# Altium schematic sheet Glue.SchDoc
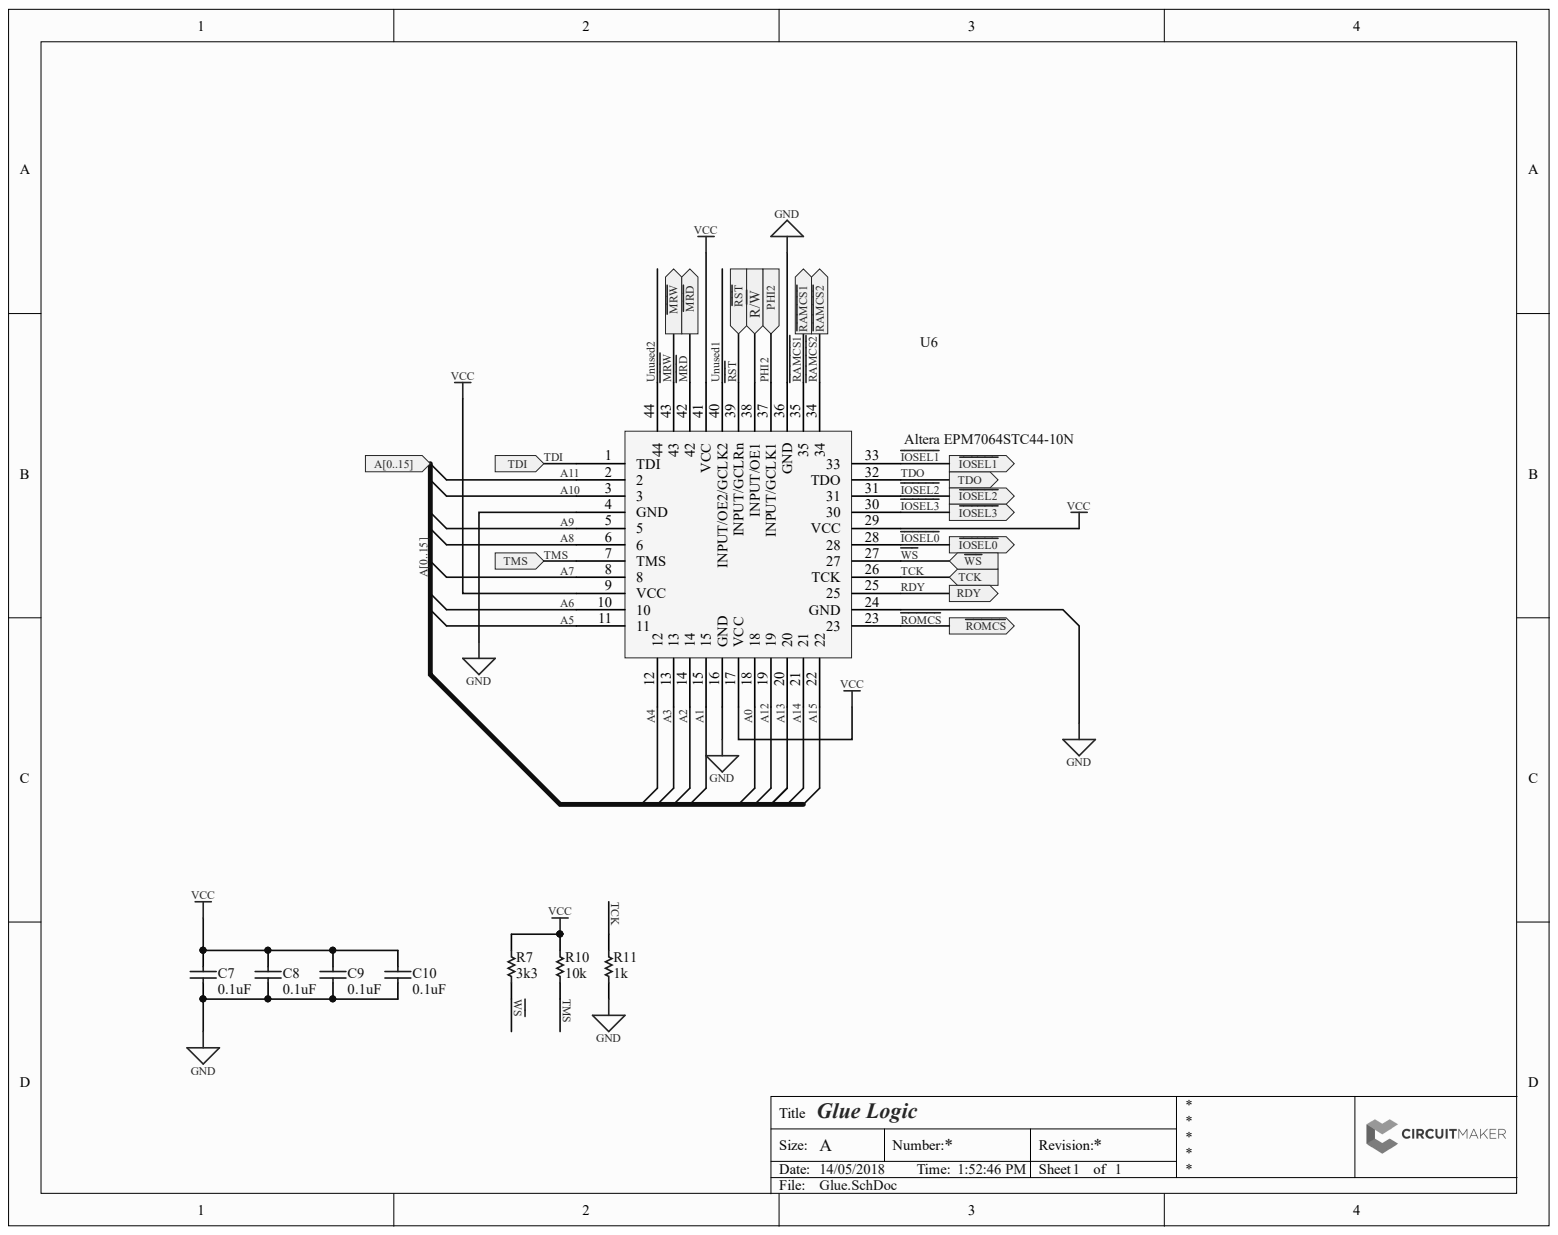
[diagram p0: Glue.SchDoc]

## Parts
C7  0.1uF  [CAPC1608X90X35NL15T15]
C8  0.1uF  [CAPC1608X90X35NL15T15]
C9  0.1uF  [CAPC1608X90X35NL15T15]
C10  0.1uF  [CAPC1608X90X35NL15T15]
R7  3k3  [RESC1608X55X30ML20T10]
R10  10k  [RESC1609X50X30NL10T20]
R11  1k  [RESC1608X55X30NL15T15]
U6  Altera EPM7064STC44-10N  [PCB-3kl8984h228uy08r94zq-1]

## Ports
A0, A1, A2, A3, A4, A5, A6, A7, A8, A9, A10, A11, A12, A13, A14, A15, A[0..15], PHI2, R/~{W}, RDY, TCK, TDI, TDO, TMS, ~{IOSEL0}, ~{IOSEL1}, ~{IOSEL2}, ~{IOSEL3}, ~{MRD}, ~{MRW}, ~{RAMCS1}, ~{RAMCS2}, ~{ROMCS}, ~{RST}, ~{WS}

## Nets
GND: C7.2 C8.2 C9.2 C10.2 R11.1 U6.4(GND) U6.16(GND) U6.24(GND) U6.36(GND)
VCC: C7.1 C8.1 C9.1 C10.1 R7.1 R10.2 U6.9(VCC) U6.17(VCC) U6.29(VCC) U6.41(VCC)
A0: U6.18 ; port A[0..15]  [also labelled A]
A1: U6.15 ; port A[0..15]  [also labelled A]
A2: U6.14 ; port A[0..15]  [also labelled A]
A3: U6.13 ; port A[0..15]  [also labelled A]
A4: U6.12 ; port A[0..15]  [also labelled A]
A5: U6.11 ; port A[0..15]  [also labelled A]
A6: U6.10 ; port A[0..15]  [also labelled A]
A7: U6.8 ; port A[0..15]  [also labelled A]
A8: U6.6 ; port A[0..15]  [also labelled A]
A9: U6.5 ; port A[0..15]  [also labelled A]
A10: U6.3 ; port A[0..15]  [also labelled A]
A11: U6.2 ; port A[0..15]  [also labelled A]
A12: U6.19 ; port A[0..15]  [also labelled A]
A13: U6.20 ; port A[0..15]  [also labelled A]
A14: U6.21 ; port A[0..15]  [also labelled A]
A15: U6.22 ; port A[0..15]  [also labelled A]
~{IOSEL0}: U6.28 ; port ~{IOSEL0}
~{IOSEL1}: U6.33 ; port ~{IOSEL1}
~{IOSEL2}: U6.31 ; port ~{IOSEL2}
~{IOSEL3}: U6.30 ; port ~{IOSEL3}
~{MRD}: U6.42 ; port ~{MRD}
~{MRW}: U6.43 ; port ~{MRW}
PHI2: U6.37(INPUT/GCLK1) ; port PHI2
R/~{W}: U6.38(INPUT/OE1) ; port R/~{W}
~{RAMCS1}: U6.35 ; port ~{RAMCS1}
~{RAMCS2}: U6.34 ; port ~{RAMCS2}
~{ROMCS}: U6.23 ; port ~{ROMCS}
~{RST}: U6.39(INPUT/GCLRn) ; port ~{RST}
RDY: U6.25 ; port RDY
TCK: R11.2 U6.26(TCK) ; port TCK
TDI: U6.1(TDI) ; port TDI
TDO: U6.32(TDO) ; port TDO
TMS: R10.1 U6.7(TMS) ; port TMS
UNUSED1: U6.40(INPUT/OE2/GCLK2)
UNUSED2: U6.44
~{WS}: R7.2 U6.27 ; port ~{WS}

## Library data of the parts
C7-C10 = 0603YC104KAT2A: 0603 0.1uF Capacitor; Capacitance: 1e-07 F; Capacitance Tolerance: ±10%; Dielectric Characteristic: X7R; Dielectric Material: Ceramic Multilayer; Insulation Resistance: 1e+11 Ω; Lead-Free Status: Lead Free; Voltage Rating (DC): 16.0 V; Case/Package: 0603; Component Kind: Standard; Library Name: Octopart library; Mounting Style: Surface Mount; Number of Pins: 2; OctopartID: 0cd5c6920958d312; Octopart Url: https://octopart.com/0603yc104kat2a-avx+interconnect+%2F+elco-55386347; REACH SVHC Compliance: No SVHC; RoHS: Compliant; Simulation: SIM-9frhrb8yuhxfmab8hy02-1; Size-Height: 0.00086 m; Size-Length: 0.0016002 m; Size-Width: 0.0008128 m
R7 = CMP-3658446-2: Thick Film Resistors - SMD 1/10watt 3.3Kohms 1%; Lead-Free Status: Lead Free; Power Rating: 0.1 W; Resistance: 3300.0 Ω; Case/Package: 0603; Component Kind: Standard; Library Name: CommunityVault; Mounting Style: Surface Mount; OctopartID: 55949cd3100e8a53; Octopart Url: https://octopart.com/rk73h1jttd3301f-koa+speer-5071100; Resistance Tolerance: ±1%; RoHS: Compliant
R10 = CMP-2823404-2: Res Thick Film 0603 10K Ohm 1% 0.1W(1/10W) ±100ppm/C Molded SMD Automotive Paper T/R; Lead-Free Status: Lead Free; Resistance: 10000.0 Ω; Voltage Rating (DC): 75.0 V; Case/Package: 0603; Component Kind: Standard; Library Name: CommunityVault; Number of Pins: 2; OctopartID: a8d6635b7b4a7711; Octopart Url: https://octopart.com/crcw060310k0fkeb-vishay-42465018; Packaging: Cut Tape (CT); Power Rating: 0.1 W; Resistance Tolerance: ±1%; RoHS: Compliant
R11 = CMP-a70b6f50b25278cc-3: RESISTOR, ANTI SULFUR, 1K, 0603, 5%; Resistance: 1kohm; Resistance Tolerance: ± 5%; Power Rating: 100mW; Voltage Rati...; Manufacturer Part Number: MCMR06X102 JTL; Resistance: 1.00 kΩ; Case/Package: 0603, 1608; Component Kind: Standard; Library Name: CommunityVault; Mounting Style: Surface Mount; OctopartID: a70b6f50b25278cc; Packaging: Cut Tape (CT); Power Rating: 100 mW; REACH SVHC Compliance: No SVHC; Resistance Tolerance: ±5%; RoHS: Compliant; Simulation: SIM-fbd72mjpv12wvr63f4ju-1
U6 = CMP-4ec387cff6eb9104-2: IC: CPLD; SMD; TQFP44; Series: Max 7000; Amount of macr; Frequency: 125 MHz; Number of Gates: 1250; Case/Package: TQFP; Component Kind: Standard; Library Name: CommunityVault; Mounting Style: Surface Mount; Number of Pins: 44; REACH SVHC Compliance: No SVHC
